# Altium schematic sheet supply.SchDoc(supply)
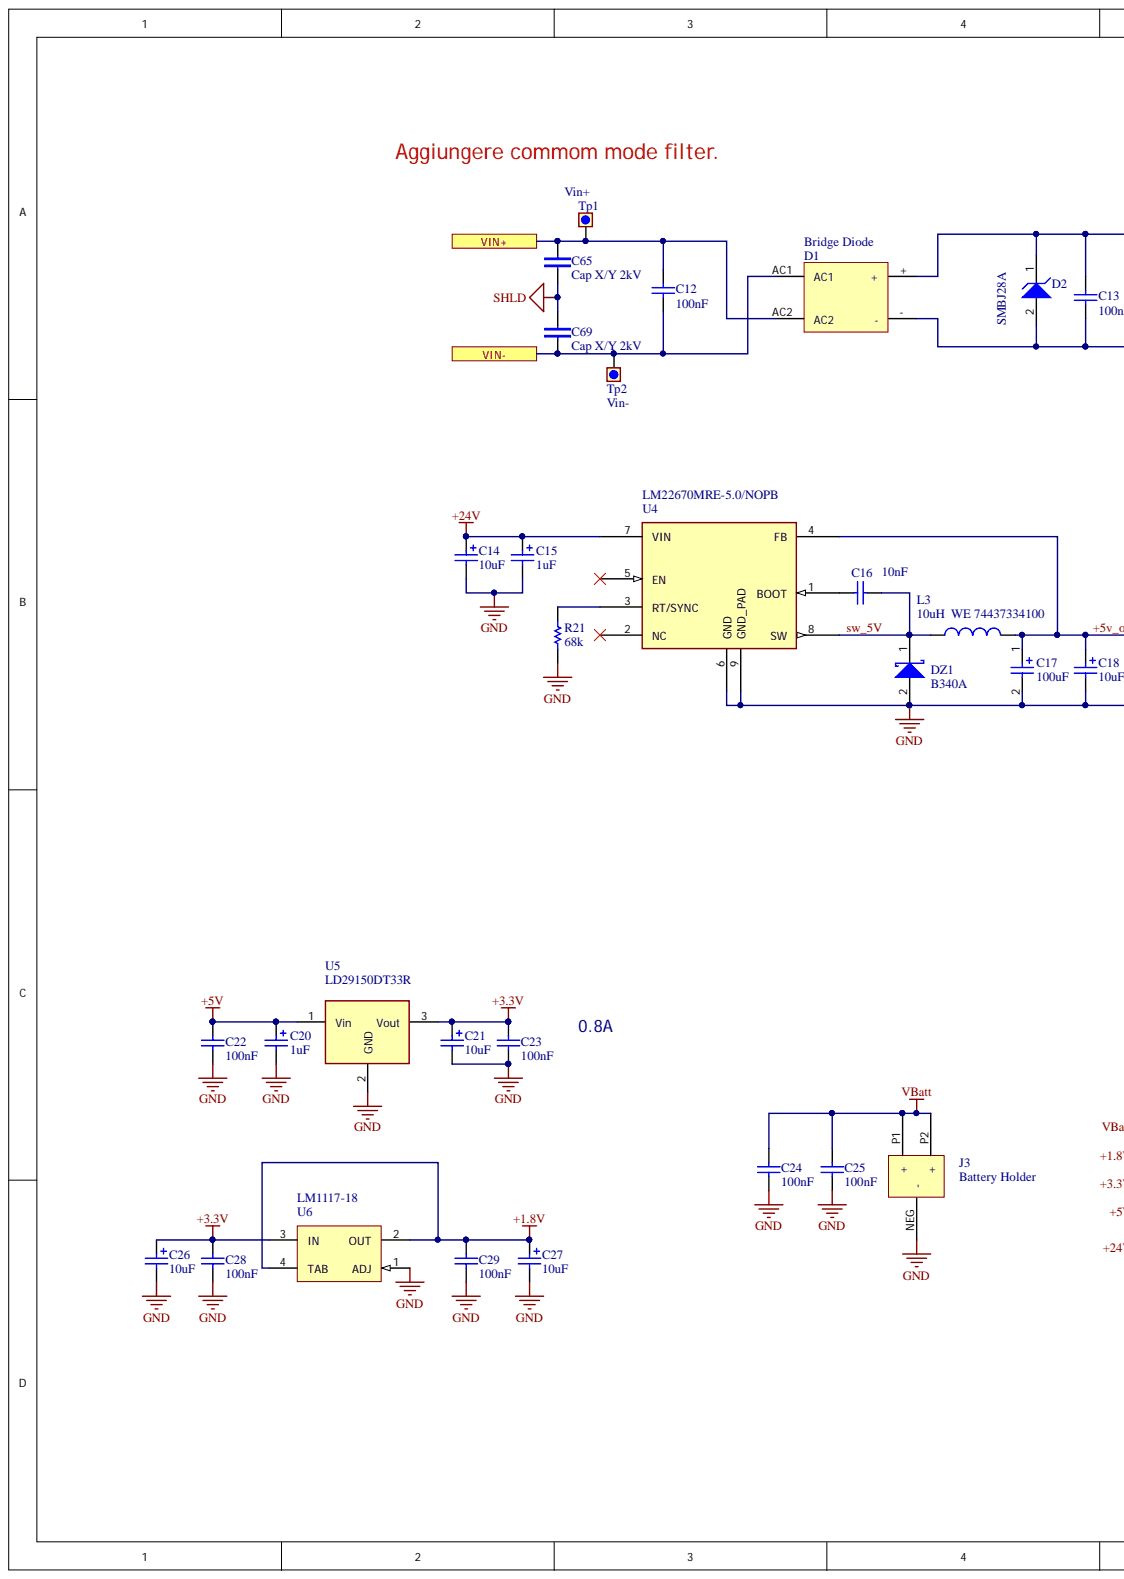
[diagram p0: supply.SchDoc, left]
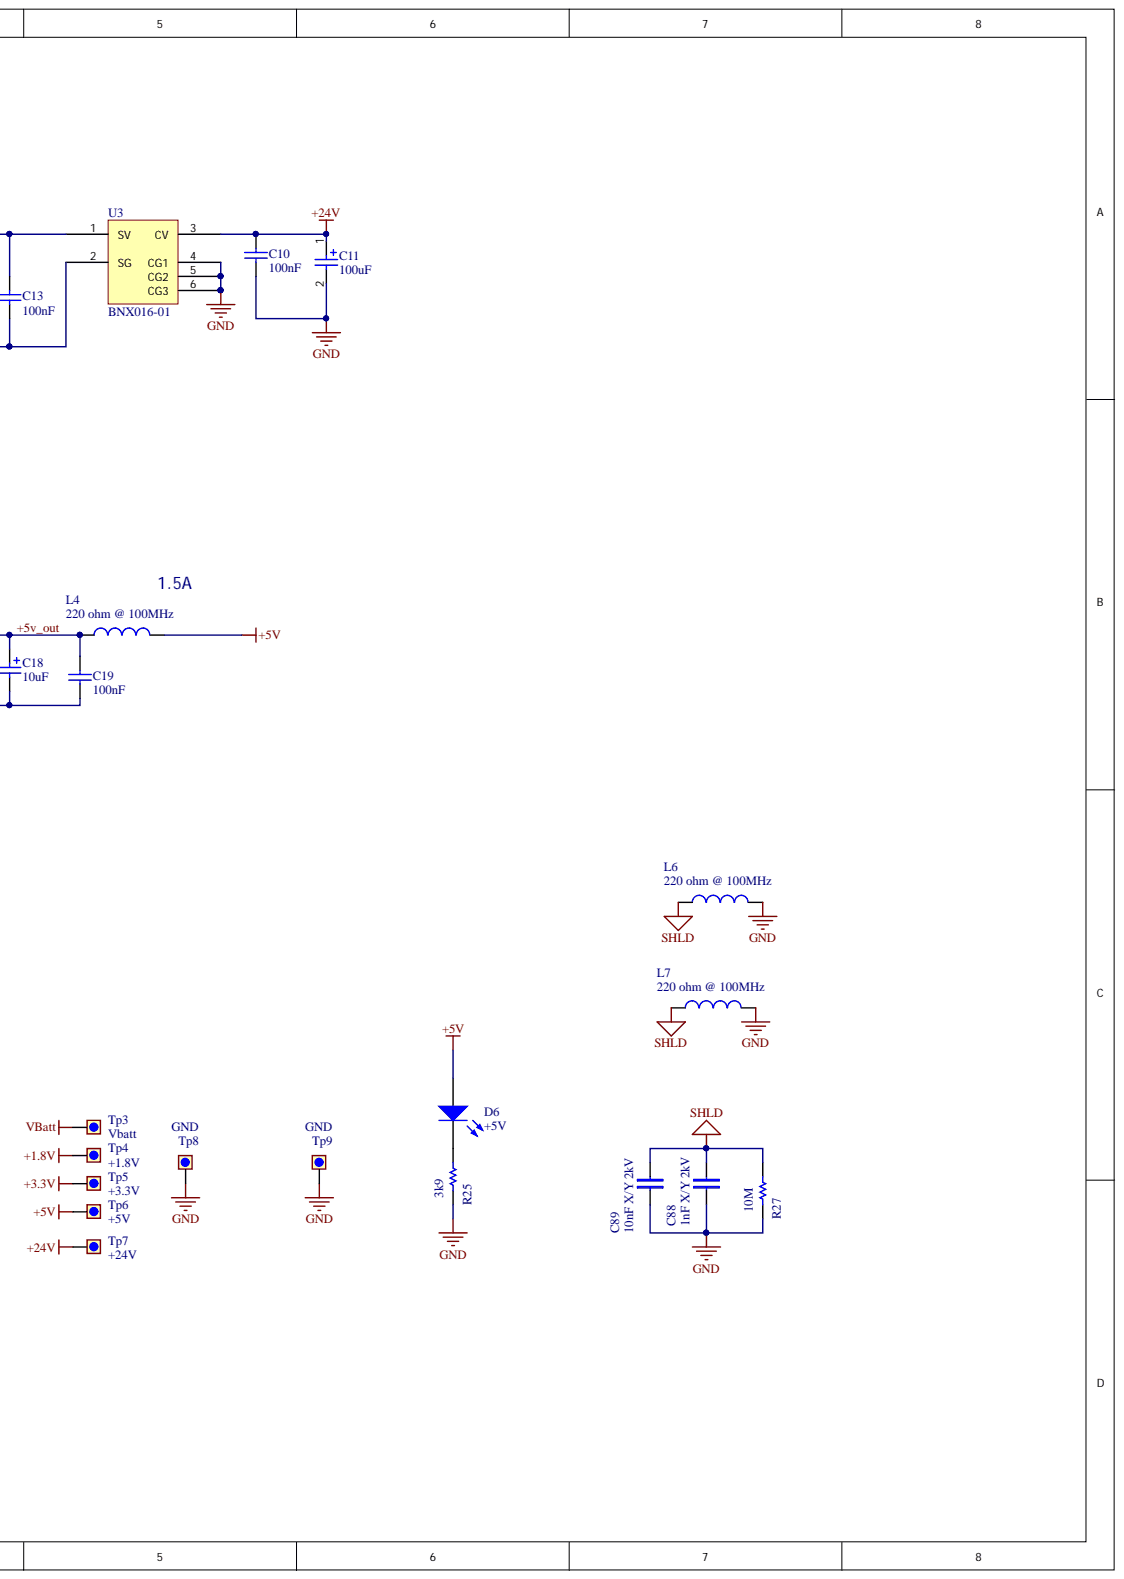
[diagram p1: supply.SchDoc, right]

## Parts
C10  100nF  [0603_[1608]]
C11  100uF  [CAP_6x6_D8_SMD]
C12  100nF  [0603_[1608]]
C13  100nF  [0603_[1608]]
C14  10uF  [1206_[3216]]
C15  1uF  value 10uF  [0805_[2012]]
C16  10nF  [0603_[1608]]
C17  100uF  [[7343]_CAP_TAN]
C18  10uF  [1206_[3216]]
C19  100nF  [0603_[1608]]
C20  1uF  [0805_[2012]]
C21  10uF  [1206_[3216]]
C22  100nF  [0603_[1608]]
C23  100nF  [0603_[1608]]
C24  100nF  [0603_[1608]]
C25  100nF  [0603_[1608]]
C26  10uF  [1206_[3216]]
C27  10uF  [1206_[3216]]
C28  100nF  [0603_[1608]]
C29  100nF  [0603_[1608]]
C65  Cap X/Y 2kV  [1808_[4520]]
C69  Cap X/Y 2kV  [1808_[4520]]
C88  1nF X/Y 2kV  [1808_[4520]]
C89  10nF X/Y 2kV  [2220_[5650]]
D1  Bridge Diode  [Diode_bridge_smd]
D2  SMBJ28A  [DO214AA_K1-A2_SMB]
D6  +5V  [0603_[1608]_K2-A1_LED]
DZ1  B340A  [DO214AA_K1-A2_SMB]
J3  Battery Holder  [Battery_holder_16mm_thd]
L3  10uH  WE 74437334100  [WE-LHMI_5020]
L4  220 ohm @ 100MHz  [0805_[2012]]
L6  220 ohm @ 100MHz  [0805_[2012]]
L7  220 ohm @ 100MHz  [0805_[2012]]
R21  68k  [0603_[1608]]
R25  3k9  [0603_[1608]]
R27  10M  [1206_[3216]]
Tp1  Vin+  [TEST_POINT_THD_D1.8MM]  (no BOM)
Tp2  Vin-  [TEST_POINT_THD_D1.8MM]  (no BOM)
Tp3  Vbatt  [TEST_POINT_THD_D1.8MM]  (no BOM)
Tp4  +1.8V  [TEST_POINT_THD_D1.8MM]  (no BOM)
Tp5  +3.3V  [TEST_POINT_THD_D1.8MM]  (no BOM)
Tp6  +5V  [TEST_POINT_THD_D1.8MM]  (no BOM)
Tp7  +24V  [TEST_POINT_THD_D1.8MM]  (no BOM)
Tp8  GND  [TEST_POINT_THD_D1.8MM]  (no BOM)
Tp9  GND  [TEST_POINT_THD_D1.8MM]  (no BOM)
U3  BNX016-01  [BNX001]
U4  LM22670MRE-5.0/NOPB  [SOIC8_PAD]
U5  LD29150DT33R  [TO-252_D2PAK_LARGEPAD]
U6  LM1117-18  [SOT-223]

## Ports
VIN+, VIN-

## Nets
+1.8V: C27.1 C29.2 Tp4.1 U6.2(OUT) U6.4(TAB)
+3.3V: C21.1 C23.2 C26.1 C28.2 Tp5.1 U5.3 U6.3(IN)
+5V: C20.1 C22.2 D6.1 L4.1 Tp6.1 U5.1
+24V: C10.2 C11.1 C14.1 C15.1 Tp7.1 U3.3(CV) U4.7(VIN)
GND: C10.1 C11.2 C14.2 C15.2 C17.2 C18.2 C19.1 C20.2 C21.2 C22.1 C23.1 C24.1 C25.1 C26.2 C27.2 C28.1 C29.1 C88.2 C89.2 DZ1.2 J3.NEG L6.1 L7.1 R21.1 R25.1 R27.1 Tp8.1 Tp9.1 U3.4(CG1) U3.5(CG2) U3.6(CG3) U4.6(GND) U4.9(GND_PAD) U5.2 U6.1(ADJ)
SHLD: C65.2 C69.1 C88.1 C89.1 L6.2 L7.2 R27.2
VBatt: C24.2 C25.2 J3.P1 J3.P2 Tp3.1
+5v_out: C17.1 C18.1 C19.2 L3.2 L4.2 U4.4(FB)
sw_5V: C16.2 DZ1.1 L3.1 U4.8(SW)
VIN+: C12.2 C65.1 D1.AC2 Tp1.1 ; port VIN+
VIN-: C12.1 C69.2 D1.AC1 Tp2.1 ; port VIN-
NetC13_1: C13.1 D1.- D2.2 U3.2(SG)
NetC13_2: C13.2 D1.+ D2.1 U3.1(SV)
NetC16_1: C16.1 U4.1(BOOT)
NetD6_2: D6.2 R25.2
NetR21_2: R21.2 U4.3(RT/SYNC)

Not connected: U4 2(NC) 5(EN)

## Library data of the parts
C10 C12 C13 C16 C19 C22-C25 C28 C29 = Cap: Ceramic X7R 50V; Value: 100pF; Simulation: CAP; Signal Integrity: Cap; Published: 8-Jun-2000; PackageReference: RAD-0.3; PackageDescription: Radial Cap, Thru-Hole; 2 Leads; 0.3 in Pin Spacing; LatestRevisionNote: Re-released for DXP Platform.; LatestRevisionDate: 17-Jul-2002; Component Kind: Standard; Publisher: Altium Limited
  C16: Library Name: Miscellaneous Devices.IntLib
  C10 C12 C13 C19 C22-C25 C28 C29: Library Name: DEVELER_Library.SchLib
C11 = Cap Pol1: EEEFK1H101P SMT 6x6 35V; Value: 10uF; Simulation: CAP; Signal Integrity: Cap Pol; Revision: July-2002: Re-released for DXP Platform.; Published: 8-Jun-2000; Component Kind: Standard; Publisher: Altium Limited; Library Name: DEVELER_Library.SchLib
C14 C18 C21 C26 C27 = Cap Pol1: Ceramic X5R 25V; Value: 10uF; Simulation: CAP; Signal Integrity: Cap Pol; Revision: July-2002: Re-released for DXP Platform.; Published: 8-Jun-2000; Component Kind: Standard; Publisher: Altium Limited; Library Name: DEVELER_Library.SchLib
C15 = Cap Pol1: Ceramic X7R 50V; Simulation: CAP; Signal Integrity: Cap Pol; Revision: July-2002: Re-released for DXP Platform.; Published: 8-Jun-2000; Component Kind: Standard; Publisher: Altium Limited; Library Name: DEVELER_Library.SchLib
C17 = Cap Pol1: Tantalum 10V (T495D107K010ATE100); Value: 10uF; Simulation: CAP; Signal Integrity: Cap Pol; Revision: July-2002: Re-released for DXP Platform.; Published: 8-Jun-2000; Component Kind: Standard; Publisher: Altium Limited; Library Name: DEVELER_Library.SchLib
C20 = Cap Pol1: Ceramic X7R 50V; Value: 10uF; Simulation: CAP; Signal Integrity: Cap Pol; Revision: July-2002: Re-released for DXP Platform.; Published: 8-Jun-2000; Component Kind: Standard; Publisher: Altium Limited; Library Name: DEVELER_Library.SchLib
C65 C69 = cap_xy: Ceramic 1nF 1808 250VAC X7R X1/Y1 (Murata GA342DR7GF102KW); Component Kind: Standard; Library Name: develer_library.schlib
C88 = cap_xy: Ceramic 250VAC X7R X1/Y1 (GA342DR7GF102KW); Component Kind: Standard; Library Name: develer_library.schlib
C89 = cap_xy: Ceramic 250VAC X7R X1/Y1 (GA355DR7GB103KY02L); Component Kind: Standard; Library Name: develer_library.schlib
D1 = Diode_bridge2: MBS4 (Farnell cod:4084985); Component Kind: Standard; Library Name: DEVELER_Library.SchLib
D2 = D Zener: TRANSIL (SM6T12A); Simulation: ZENER; Signal Integrity: D Zener; Published: 8-Jun-2000; PackageReference: DIODE-0.7; PackageDescription: Diode, Thru-Hole; 2 Leads; 0.7 in Pin Spacing; LatestRevisionNote: Re-released for DXP Platform.; LatestRevisionDate: 17-Jul-2002; Component Kind: Standard; Publisher: Altium Limited; Library Name: DEVELER_Library.SchLib
D6 = LED0: LED Green; Simulation: LED0; Published: 8-Jun-2000; PackageReference: LED-0; PackageDescription: LED; 2 Leads; LatestRevisionNote: Mech Layer 1 removed.; LatestRevisionDate: 08-Jul-2005; Component Kind: Standard; Publisher: Altium Limited; Library Name: DEVELER_Library.SchLib
DZ1 = D Schottky: Diode Schottky (STPS2L40U); Simulation: SKYDIODE; Signal Integrity: D Schottky; Published: 8-Jun-2000; PackageReference: SMB; PackageDescription: DO-214-AA/SMB; 2 C-Bend Leads; Body 5.3 x 3.6 mm, inc. leads (LxW); Library Name: Miscellaneous Devices.IntLib; LatestRevisionNote: Re-released for DXP Platform.; LatestRevisionDate: 17-Jul-2002; Component Kind: Standard; Code_JEDEC: DO-214-AA; Publisher: Altium Limited
J3 = Battery_holder_3002: CR1612/1616/1620/1632 (3013, Keystone); Component Kind: Standard; Library Name: DEVELER_Library.SchLib
L3 = Inductor: SMD Shielded Tiny Power Inductor; Value: 10mH; Code_IEC: 1005; Simulation: INDUCTOR; Signal Integrity: Inductor; Published: 8-Jun-2000; PackageReference: 0402-A; PackageDescription: Chip Inductor; Library Name: Miscellaneous Devices.IntLib; LatestRevisionNote: IPC-7351 Footprint Added.; LatestRevisionDate: 29-May-2009; Component Kind: Standard; Code_JEITA: 0402; Publisher: Altium Limited
L4 L6 L7 = Inductor: WE 742792022; Component Kind: Standard; Library Name: DEVELER_Library.SchLib
R21 R25 R27 = Res1: Resistor; Simulation: RESISTOR; Signal Integrity: Res; Revision: July-2002: Re-released for DXP Platform.; Component Kind: Standard
  R21 R25: Library Name: DEVELER_Library.SchLib
  R27: Library Name: develer_library.schlib
Tp1-Tp9 = Test_point: Test point; Library Name: DEVELER_Library.SchLib
U3 = BNX002: Library Name: STR910_SCHLIB.SCHLIB; Component Kind: Standard
U4 = LM22670MR-ADJ: Power switch; Component Kind: Standard; Library Name: DEVELER_Library.SchLib
U5 = LD29150DT33R: Very Low Drop Linear regulator 3.3V (BA33BC0FP-E2; MIC2940A-3.3WU); Component Kind: Standard; Library Name: DEVELER_Library.SchLib
U6 = LM317AEMP: LDO, Fixed Vout = 1.8V; Manufacturer: National Semiconductor; PowerWise: N; PackageTopMarking: XYTT*N07A; CompType: LDO IC; Supplier Part Number 2: 9779272; Supplier Part Number 1: 9779272RL; Supplier 2: Farnell; Supplier 1: Farnell; RoHS: N; Published: 4-Dec-2008; PinCount: 4; PartNumber: LM317AEMP; PackageVersion: revB, Apr-2003; PackageReference: MP04A; PackageDescription: Molded SOT-223, 6.50 x 3.56 x 1.6mm Body, 4 Lead, 2.3mm Pitch; DatasheetVersion: Apr-2007; ComponentLink3URL: http://www.national.com/packaging/folders/mp04a.html; ComponentLink3Description: MP04A Package Page; ComponentLink2URL: http://www.national.com/pf/LM/LM317.html; ComponentLink2Description: LM317 Web Page; ComponentLink1URL: http://www.national.com/ds/LM/LM317.pdf; ComponentLink1Description: LM317 Datasheet; Component Kind: Standard; Code_JEDEC: TO-261-AA; Code_IPC: SOT223-4; Publisher: Altium Limited; Library Name: DEVELER_Library.SchLib
